# Altium schematic sheet IO.SchDoc
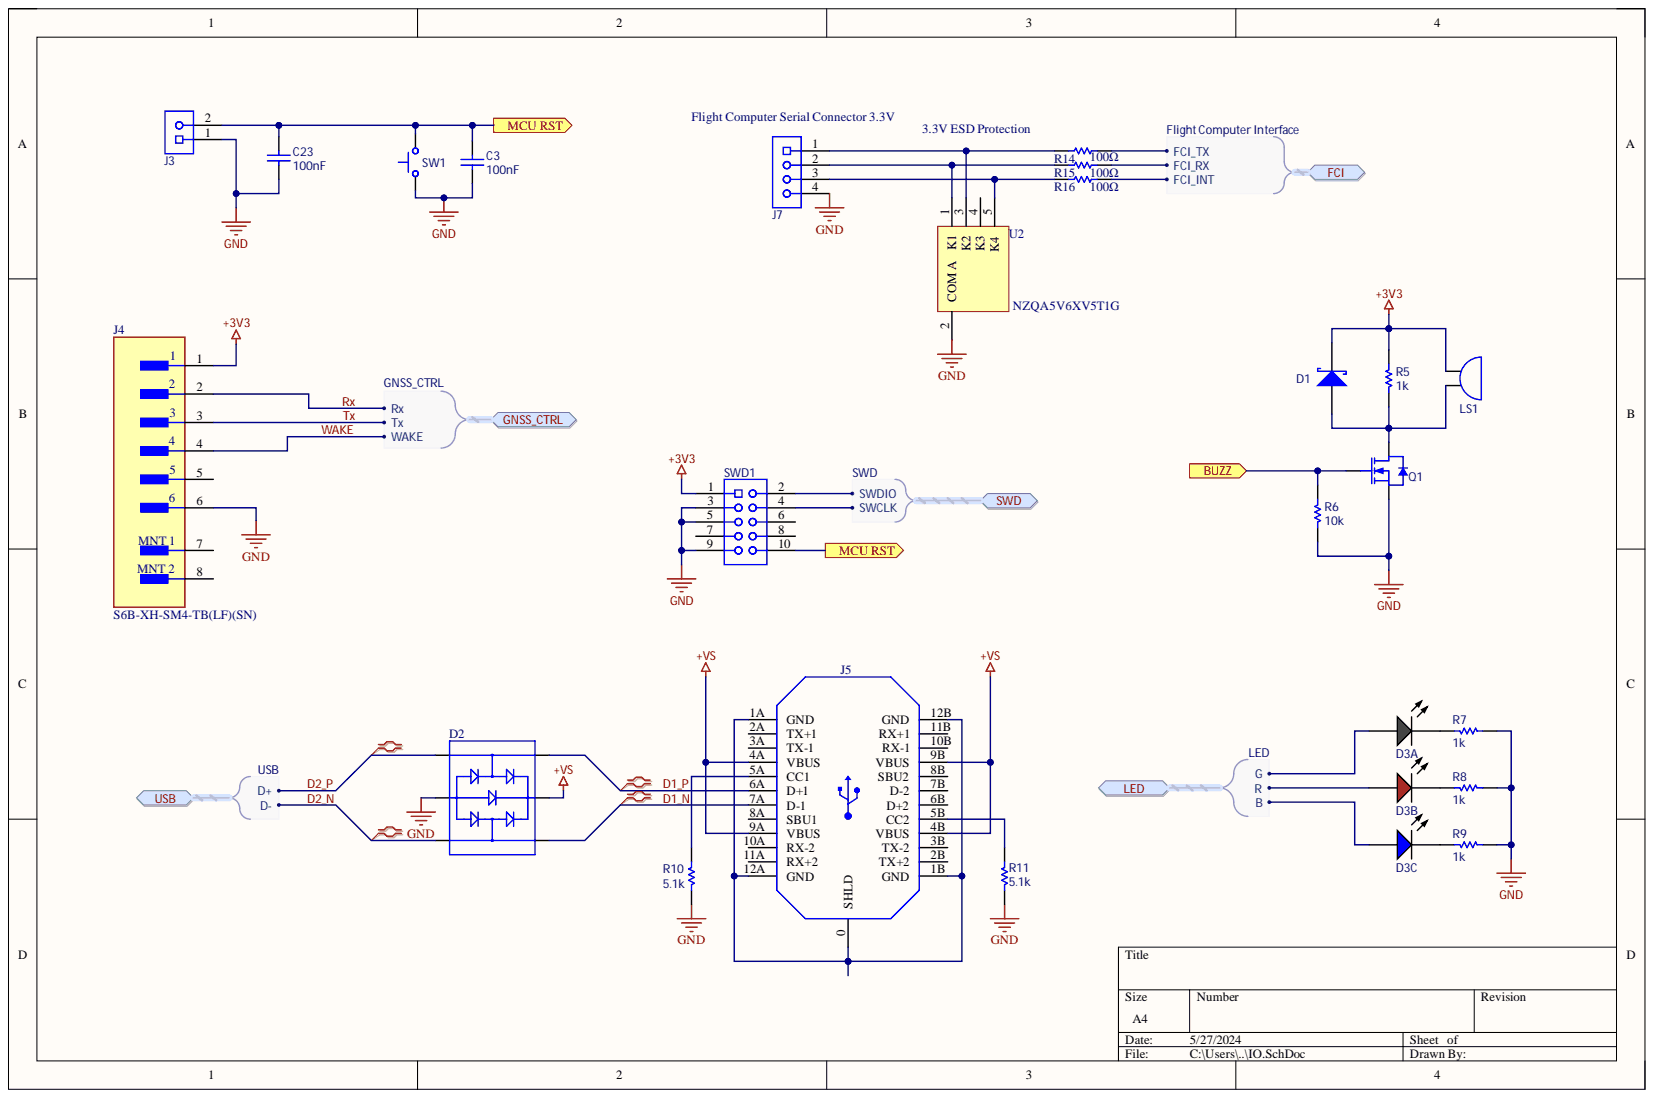
[diagram p0: IO.SchDoc]

## Parts
C3  value 100nF  Capacitance: 100nF  [CAPC1608X10N]  (hidden comment: C0603C104M5RACTU)
C23  value 100nF  Capacitance: 100nF  [CAPC1608X10N]  (hidden comment: C0603C104M5RACTU)
D1  RB521S30T1G  [SODFL160X70-2N]  (value not drawn)
D2  USBLC6-2SC6  [USBLC6-2SC6-Footprint-1]  (value not drawn)
D3  LRTBGVSR-U4V2-JW+A6BB-D8+S2U2-7Z-20-S  [LRTBGVSG-UEVE-24+AMAQ-29+SCUC-HR-Footprint-1]  (value not drawn)  (parts A,B,C)
J3  22-28-4020  [22-28-4020-Footprint-1]  (value not drawn)
J4  S6B-XH-SM4-TB(LF)(SN)  [FP-S6B-XH-SM4-TB_LF_SN-MFG]
J5  SS-52400-003  [SS-52400-003-Footprint-1]  (value not drawn)
J7  53426-0410  [53426-0410-Footprint-1]  (value not drawn)
LS1  CMT-8503-85B-SMT-TR  [CMT-8503-85B-SMT-TR-Footprint-1]  (value not drawn)
Q1  2N7002NXAKR  [SOT95P230X110-3N]  (value not drawn)
R5  value 1k  [RESC1608X06N]  (hidden comment: CRCW06031K00FKEA)
R6  value 10k  [RESC1608X06N]  (hidden comment: CRCW060310K0FKEA)
R7  value 1k  [RESC1608X06N]  (hidden comment: CRCW06031K00FKEA)
R8  value 1k  [RESC1608X06N]  (hidden comment: CRCW06031K00FKEA)
R9  value 1k  [RESC1608X06N]  (hidden comment: CRCW06031K00FKEA)
R10  value 5.1k  [RESC1608X06N]  (hidden comment: CRCW06035K10FKEA)
R11  value 5.1k  [RESC1608X06N]  (hidden comment: CRCW06035K10FKEA)
R14  100Ω  [RESC1609X50X30ML8T20]
R15  100Ω  [RESC1609X50X30ML8T20]
R16  100Ω  [RESC1609X50X30ML8T20]
SW1  B3U-1000P  [OMRON-B3U-1000_V]  (value not drawn)
SWD1  M22-2520505  [M22-2520505-Footprint-1]  (value not drawn)
U2  NZQA5V6XV5T1G  [ONSC-SOT-553-5-463B_B_V]

## Ports
BUZZ, FCI, FCI.FCI_INT, FCI.FCI_RX, FCI.FCI_TX, GNSS_CTRL, GNSS_CTRL.Rx, GNSS_CTRL.Tx, GNSS_CTRL.WAKE, LED, LED.B, LED.G, LED.R, MCU RST, SWD, SWD.SWCLK, SWD.SWDIO, USB, USB.D+, USB.D-

## Nets
+3V3: D1.1 J4.1 LS1.1 R5.2 SWD1.1
+VS: D2.5 J5.4A(VBUS) J5.4B(VBUS) J5.9A(VBUS) J5.9B(VBUS)
GND: C3.1 C23.1 D2.2 J3.1 J4.6 J7.4 Q1.2 R6.1 R7.2 R8.2 R9.2 R10.1 R11.1 SW1.2 SWD1.3 SWD1.5 SWD1.9 U2.2
BUZZ: Q1.1 R6.2 ; port BUZZ
D1_N: D2.6 J5.7A(D-1)
D1_P: D2.4 J5.6A(D+1)
D2_N: D2.1 ; port USB
D2_P: D2.3 ; port USB
MCU RST: C3.2 C23.2 J3.2 SW1.1 SWD1.10 ; port MCU RST
Rx: J4.2 ; port GNSS_CTRL
Tx: J4.3 ; port GNSS_CTRL
WAKE: J4.4 ; port GNSS_CTRL
NetD1_2: D1.2 LS1.2 Q1.3 R5.1
NetD3_1: D3.1 R7.1
NetD3_2: D3.2 ; port LED
NetD3_3: D3.3 R9.1
NetD3_4: D3.4 R8.1
NetD3_5: D3.5 ; port LED
NetD3_6: D3.6 ; port LED
NetJ4_5: J4.5
NetJ4_7: J4.7
NetJ4_8: J4.8
NetJ5_0: J5.0(SHLD) J5.1A(GND) J5.1B(GND) J5.12A(GND) J5.12B(GND)
NetJ5_2A: J5.2A(TX+1)
NetJ5_2B: J5.2B(TX+2)
NetJ5_3A: J5.3A(TX-1)
NetJ5_3B: J5.3B(TX-2)
NetJ5_5A: J5.5A(CC1) R10.2
NetJ5_5B: J5.5B(CC2) R11.2
NetJ5_6B: J5.6B(D+2)
NetJ5_7B: J5.7B(D-2)
NetJ5_8A: J5.8A(SBU1)
NetJ5_8B: J5.8B(SBU2)
NetJ5_10A: J5.10A(RX-2)
NetJ5_10B: J5.10B(RX-1)
NetJ5_11A: J5.11A(RX+2)
NetJ5_11B: J5.11B(RX+1)
NetJ7_1: J7.1 R14.2 U2.3(K2)
NetJ7_2: J7.2 R15.2 U2.1(K1)
NetJ7_3: J7.3 R16.2 U2.5(K4)
NetR14_1: R14.1 ; port FCI
NetR15_1: R15.1 ; port FCI
NetR16_1: R16.1 ; port FCI
NetSWD1_2: SWD1.2 ; port SWD
NetSWD1_4: SWD1.4 ; port SWD
NetSWD1_6: SWD1.6
NetSWD1_7: SWD1.7
NetSWD1_8: SWD1.8
NetU2_4: U2.4(K3)

## Library data of the parts
C3 C23 = CMP-00038-5: Cap Ceramic 0.1uF 50V X7R 20% SMD 0603 125C T/R; Dissipation Factor: 2.5%; Case Code (Imperial): 0603; Case Code (Metric): 1608; Case/Package: 0603; Component Kind: Standard; Contact Plating: Tin,Matte; Depth: 0.8mm; Dielectric: X7R; Dielectric Material: Ceramic; Height: 0.8mm; JLC_PN: C14663; Lead Pitch: 0.7mm; Length: 1.6mm; Max Operating Temperature: 125°C; Min Operating Temperature: -55°C; Mount: Surface Mount,PCB; Package Quantity: 1; Packaging: Tape and Reel; Radiation Hardening: No; REACH SVHC: No SVHC; Termination: SMD/SMT; Thickness: 0.034inch; Tolerance: 20%; Voltage Rating: 50V; Voltage Rating (DC): 50V; Width: 0.031inch; Library Name: UWA Aerospace
D1 = CMP-00227-2: ON SEMICONDUCTOR - RB521S30T1G - Small Signal Schottky Diode, Single, 30 V, 200 mA, 500 mV, 1 A, 125 °C; Average Rectified Current: 200mA; Forward Voltage: 500mV; Max Forward Surge Current (Ifsm): 1A; Max Repetitive Reverse Voltage (Vrrm): 30V; Max Reverse Current: 30uA; Polarity: Standard; Power Dissipation: 200mW; Case/Package: SOD-523-2; Component Kind: Standard; Contact Plating: Tin,Matte; Current Rating: 200mA; Element Configuration: Single; Forward Current: 200mA; Height: 0.7mm; Lead Free: Lead Free; Length: 1.3mm; Max Operating Temperature: 125°C; Max Reverse Leakage Current: 30uA; Max Reverse Voltage (DC): 30V; Max Surge Current: 1A; Min Operating Temperature: -55°C; Number of Pins: 2; Output Current: 200mA; Package Quantity: 1; Packaging: Tape and Reel; Peak Non-Repetitive Surge Current: 1A; Radiation Hardening: No; REACH SVHC: No SVHC; RoHS Compliant: Yes; Voltage Rating (DC): 30V; Width: 0.9mm; Library Name: UWA Aerospace
D2 = CMP-00283-1: USB ESD Supressor TVS DIODE 5.25V 17V SOT23-6; Breakdown Voltage: 6V; Capacitance: 3.5pF; Data Rate: 480Mbps; Direction: Unidirectional,Bidirectional; Element Configuration: Dual; ESD Protection: Yes; Leakage Current: 150nA; Number of Bidirectional Channels: 2; Number of Elements: 1; Operating Supply Voltage: 1.1V; Peak Pulse Current: 5A; Test Current: 1mA; Working Voltage: 5V; Case/Package: SOT-23; Clamping Voltage: 17V; Clamping Voltage-Max: 17V; Component Kind: Standard; Contact Plating: Tin,Matte; Depth: 1.75mm; Height: 1.3mm; Lead Free: Lead Free; Length: 3.05mm; Max Breakdown Voltage: 6V; Max Operating Temperature: 125°C; Max Reverse Leakage Current: 150nA; Min Breakdown Voltage: 6V; Min Operating Temperature: -40°C; Mount: Surface Mount; Number of Channels: 2; Number of Pins: 6; Package Quantity: 3000; Packaging: Tape and Reel; Polarity: Unidirectional,Bidirectional; Power Line Protection: Yes; Radiation Hardening: No; REACH SVHC: No SVHC; Reverse Breakdown Voltage: 6V; Reverse Standoff Voltage: 5.25V; RoHS Compliant: Yes; Series: USB; Termination: SMD/SMT; Width: 1.75mm; Library Name: UWA Aerospace
D3 = CMP-00027-2: LED Multicolor Red/True Green/Blue 626nm/528nm/465nm 40mA/50mA/50mA 6-Pin SMD T/R; Component Kind: Standard; Library Name: UWA Aerospace
J3 = CMP-00157-4: KK 254 Breakaway Header, Vertical, 2 Circuits, Tin (Sn) Plating, Mating Pin Length 6.09mm 2 POS; Connector Type: Male Pin; Contact Resistance: 20mR; Current Rating: 4A; Gender: Male; Glow Wire Compliant: Non-compliant; Max Voltage Rating (AC): 250V; Number of Circuits: 2; Pitch: 2.54mm; Color: Black; Component Kind: Standard; Contact Material: Brass,Bronze; Contact Mating Length: 0.24inch; Contact Plating: Tin; Contact Type: Male Pin; Depth: 2.49mm; Flammability Rating: UL94 V-0; Housing Color: Black; Housing Material: Nylon; Lead Free: Lead Free; Max Operating Temperature: 75°C; Max Voltage Rating (DC): 250V; Min Operating Temperature: 0°C; Mount: Through Hole; Mounting Technology: Through Hole; Number of Contacts: 2; Number of Positions: 2; Number of Rows: 1; Orientation: Straight; Package Quantity: 1; Packaging: Bulk; Radiation Hardening: No; REACH SVHC: Unknown; Series: KK® 42375; Termination: Solder; Voltage Rating: 250V; Voltage Rating (DC): 250V; Library Name: UWA Aerospace
J4 = CMP-17439-000164-1: CONN HEADER SMD R/A 6POS 2.5MM; Contact Resistance: 20mR; Gender: Male; Max Current Rating: 3A; Max Voltage Rating (AC): 250V; Number of Contacts: 6; Color: Natural; Component Kind: Standard; Connector Type: Header; Contact Finish - Mating: TIN; Contact Material: Copper Alloy; Contact Plating: Copper; Contact Shape: Square; Contact Type: Male Pin; Depth: 7mm; Fastening Type: Detent; Flammability Rating: UL94 V-0; Housing Color: Beige; Housing Material: Polyamide; Insulation Color: Natural; Insulation Material: Nylon; Lead Free: Lead Free; Length: 20mm; Library Name: Altium Content Vault; Max Operating Temperature: 85°C; Min Operating Temperature: -25°C; Mount: Surface Mount; Number of Positions: 6; Number of Rows: 1; Orientation: Right Angle; Package Quantity: 1; Packaging: Tape and Reel; Pitch: 2.5mm; REACH SVHC: No SVHC; Series: XH; Termination: Solder; Voltage Rating: 250V
J5 = CMP-00209-1: USB Type-C Receptacle for 1.60mm PCB; Component Kind: Standard; Library Name: UWA Aerospace
J7 = CMP-00280-1: 2.50mm Pitch Mini-Lock™ Wire-to-Board Header, Right Angle, Friction and Positive Lock, 4 Circuits; Contact Resistance: 20mR; Current Rating: 3A; Gender: Male; Glow Wire Compliant: Non-compliant; Number of Circuits: 4; Pitch: 2.5mm; Voltage Rating: 250V; Case/Package: 0410; Color: White; Component Kind: Standard; Contact Gender: Male; Contact Material: Bronze; Contact Plating: Tin; Housing Material: Polyester; Max Operating Temperature: 105°C; Min Operating Temperature: -40°C; Mount: Through Hole; Number of Contacts: 4; Number of Positions: 4; Number of Rows: 1; Orientation: Right Angle; Package Quantity: 1000; Packaging: Tray; Radiation Hardening: No; REACH SVHC: No SVHC; RoHS Compliant: Yes; Series: 53426; Termination: Through Hole; Library Name: UWA Aerospace
LS1 = CMP-00287-1: 8.5 mm, 3.6 Vo-p, 85 dB, Surface Mount (SMT), Magnetic Audio Transducer Buzzer; Component Kind: Standard; Library Name: UWA Aerospace
Q1 = CMP-00122-3: 2N7002NXAK - 60 V, single N-channel Trench MOSFET; Component Kind: Standard; JLC_PN: C8545; Library Name: UWA Aerospace
R5 R7-R9 = CMP-00041-3: VISHAY - CRCW06031K00FKEA - SMD Chip Resistor, 0603 [1608 Metric], 1 kohm, CRCW e3 Series, 75 V, Thick Film, 100 mW; Max Power Dissipation: 100mW; Resistance: 1kR; Temperature Coefficient: 100ppm/°C; Tolerance: 1%; Voltage Rating: 75V; Case Code (Imperial): 0603; Case Code (Metric): 1608; Case/Package: 0603; Component Kind: Standard; Composition: Thick Film; Contact Plating: Tin; Depth: 0.85mm; Features: Automotive AEC-Q200; Height: 0.45mm; JLC_PN: C21190; Lead Free: Lead Free; Length: 1.55mm; Max Operating Temperature: 155°C; Military Standard: Not; Min Operating Temperature: -55°C; Mount: Surface Mount; Number of Pins: 2; Number of Terminations: 2; Package Quantity: 10; Packaging: Tape and Reel; Power Rating: 100mW; Radiation Hardening: No; REACH SVHC: Unknown; Termination: SMD/SMT; Voltage Rating (DC): 75V; Weight: 7.1E-05oz; Width: 0.033inch; Library Name: UWA Aerospace
R6 = CMP-00042-5: VISHAY - CRCW060310K0FKEA - SMD Chip Resistor, 0603 [1608 Metric], 10 kohm, CRCW e3 Series, 75 V, Thick Film, 100 mW; Max Power Dissipation: 100mW; Resistance: 10kR; Temperature Coefficient: 100ppm/°C; Tolerance: 1%; Voltage Rating: 75V; Case Code (Imperial): 0603; Case Code (Metric): 1608; Case/Package: 0603; Component Kind: Standard; Composition: Thick Film; Contact Plating: Tin,Lead; Depth: 0.85mm; Features: Automotive AEC-Q200; Height: 0.45mm; JLC_PN: C25804; Lead Free: Lead Free; Length: 1.55mm; Max Operating Temperature: 155°C; Military Standard: Not; Min Operating Temperature: -55°C; Number of Pins: 2; Number of Terminations: 2; Package Quantity: 10; Packaging: Tape and Reel; Power Rating: 100mW; Radiation Hardening: No; REACH SVHC: Unknown; Termination: SMD/SMT; Voltage Rating (DC): 75V; Weight: 7.1E-05oz; Width: 0.033inch; Library Name: UWA Aerospace
R10 R11 = CMP-00220-2: Res Thick Film 0603 5.1K Ohm 1% 1/10W ±100ppm/°C Molded SMD SMD Paper T/R; Max Power Dissipation: 100mW; Voltage Rating: 75V; Case Code (Imperial): 0603; Case Code (Metric): 1608; Case/Package: 0603; Component Kind: Standard; Construction: Rectangular; Contact Plating: Tin; Depth: 0.85mm; Features: Automotive AEC-Q200; Height: 0.45mm; JLC_PN: C23186; Lead Free: Lead Free; Length: 1.55mm; Max Operating Temperature: 155°C; Military Standard: Not; Min Operating Temperature: -55°C; Number of Terminations: 2; Package Quantity: 5000; Packaging: Tape and Reel; Power Rating: 100mW; Radiation Hardening: No; Reach SVHC Compliant: Unknown; Resistance Tolerance: 1%; Resistor Type: Thick Film; RoHS Compliant: Yes; Series: CRCW; Technology: THICK FILM; Temperature Coefficient: 100ppm/°C; Termination: SMD/SMT; Tolerance: 1%; Voltage Rating (DC): 75V; Weight: 7.1E-05oz; Width: 0.85mm; Library Name: UWA Aerospace
R14-R16 = CMP-2000-03824-5: Chip Resistor, 100 Ohm, +/- 1%, 100 mW,-55 to 155 degC, 0603 (1608 Metric), RoHS, Tape and Ree; Manufacturer: Vishay Semiconductor; Component Kind: Standard; ComponentLink1Description: Manufacturer URL; ComponentLink1URL: http://www.vishay.com/; ComponentLink2Description: Datasheet; ComponentLink2URL: http://www.vishay.com/docs/20035/dcrcwe3.pdf; DatasheetVersion: 06/2012; Library Name: Altium Content Vault; Mounting Technology: Surface Mount; PackageDescription: 2-Pin Surface Mount Device, Body 1.575 x 0.85 mm; PackageReference: 0603
SW1 = CMP-00028-1: SWITCH TACTILE SPST-NO 0.05A 12V; Actuator Length: 0.4mm; Actuator Type: Standard; Contact Current Rating: 50mA; Contact Resistance: 100mR; Electrical Life: 200000Cycles; Illumination Type: Non-Illuminated,Illuminated; Operating Force: 1.5N; Ratings: Dust Proof,IP40; Switch Function: Off-Mom; Switch Travel: 0.15mm; Throw Configuration: SPST; Component Kind: Standard; Contact Material: Silver; Current Rating: 50mA; Depth: 2.5mm; Height: 1.2mm; Insulation Resistance: 100MR; Lead Free: Lead Free; Length: 4mm; Max Current Rating: 50mA; Max Operating Temperature: 70°C; Max Voltage Rating (DC): 12V; Mechanical Life: 200000Cycles; Min Operating Temperature: -25°C; Mount: Surface Mount; Orientation: Straight; Package Quantity: 1; Packaging: Tape and Reel; REACH SVHC: Unknown; Termination: SMD/SMT; Voltage Rating (DC): 12V; Weight: 0.000776oz; Width: 3mm; Library Name: UWA Aerospace
SWD1 = CMP-00022-1: CONN HEADER 2MM VERT AU 10POS; Connector Type: Male Pin; Contact Resistance: 20mR; Current Rating: 1A; Gender: Male; Number of Contacts: 10; Row Spacing: 0.079inch; Voltage Rating: 650V; Color: Black; Component Kind: Standard; Contact Material: Bronze; Contact Mating Length: 0.138inch; Contact Plating: Gold; Depth: 4mm; Housing Color: Black; Housing Material: Nylon; Length: 10mm; Max Current Rating: 1A; Max Operating Temperature: 105°C; Min Operating Temperature: -55°C; Mount: Through Hole; Number of Positions: 10; Number of Rows: 2; Orientation: Straight; Package Quantity: 500; Packaging: Bulk; Pitch: 2mm; Radiation Hardening: No; REACH SVHC: No SVHC; Termination: Solder; Library Name: UWA Aerospace
U2 = CMP-1063-00192-1: Quad Array for ESD Protection, 5-Pin SOT-553, Pb-Free, Tape and Reel; Manufacturer: On Semiconductor; C Max (pF): 90; Direction: Unidirectional; IR Max (uA): 1; Number of Lines: 4; PPK Max (W): 100; V(BR) Min (V): 5.32; VRWM Max (V): 3; Component Kind: Standard; ComponentLink1Description: Manufacturer URL; ComponentLink1URL: http://www.onsemi.com/; ComponentLink2Description: Datasheet; ComponentLink2URL: http://www.onsemi.com/pub_link/Collateral/NZQA5V6XV5T1-D.PDF; ComponentLink3Description: Package Specification; ComponentLink3URL: http://www.onsemi.com/pub_link/Collateral/NZQA5V6AXV5%20SERIES-D.PDF; DatasheetVersion: Rev. 3; Library Name: Altium Content Vault; Mounting Technology: Surface Mount; PackageDescription: 5-Pin Small Outline Transistor, Body 1.6 x 1.2 mm, Pitch 0.5 mm; PackageReference: SOT-553-5-463B_B; PackageVersion: Rev. B, 05/2005; Packing: Tape and Reel; PartNumber: NZQA5V6XV5T1G; RoHS: Pb-Free
